# Altium schematic sheet current_sens.SchDoc("Current sens 5V")
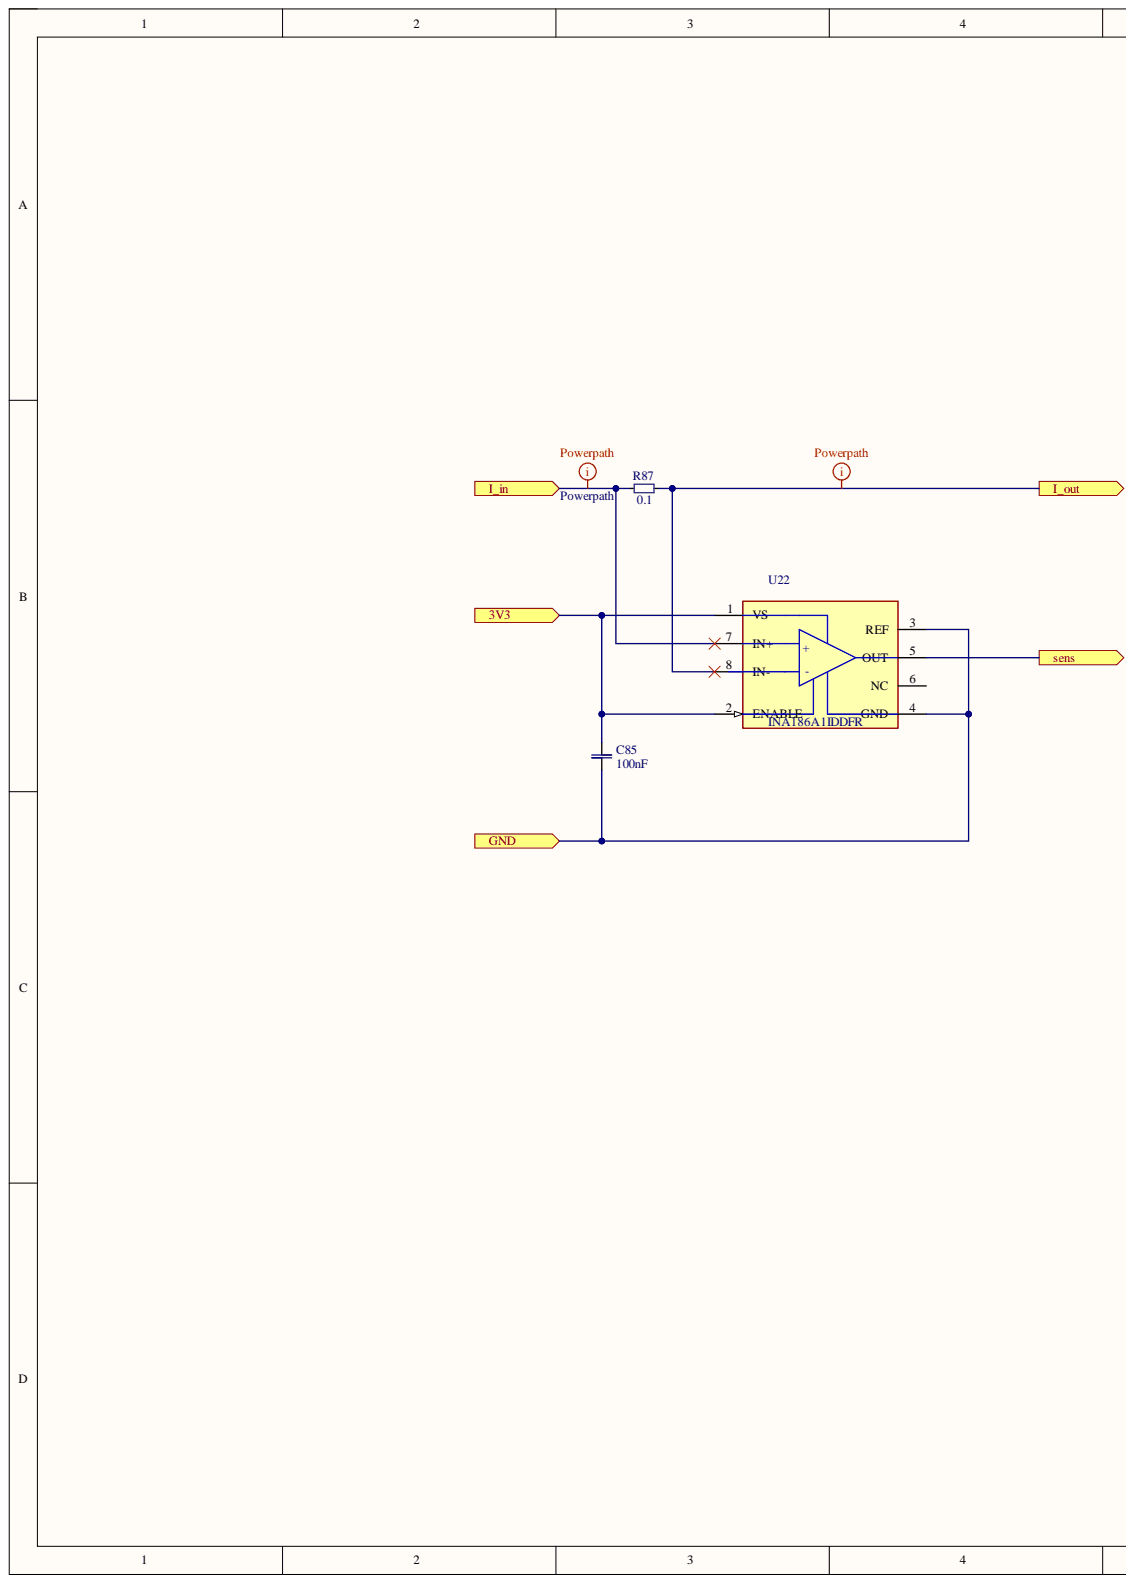
[diagram p0: current_sens.SchDoc, left]
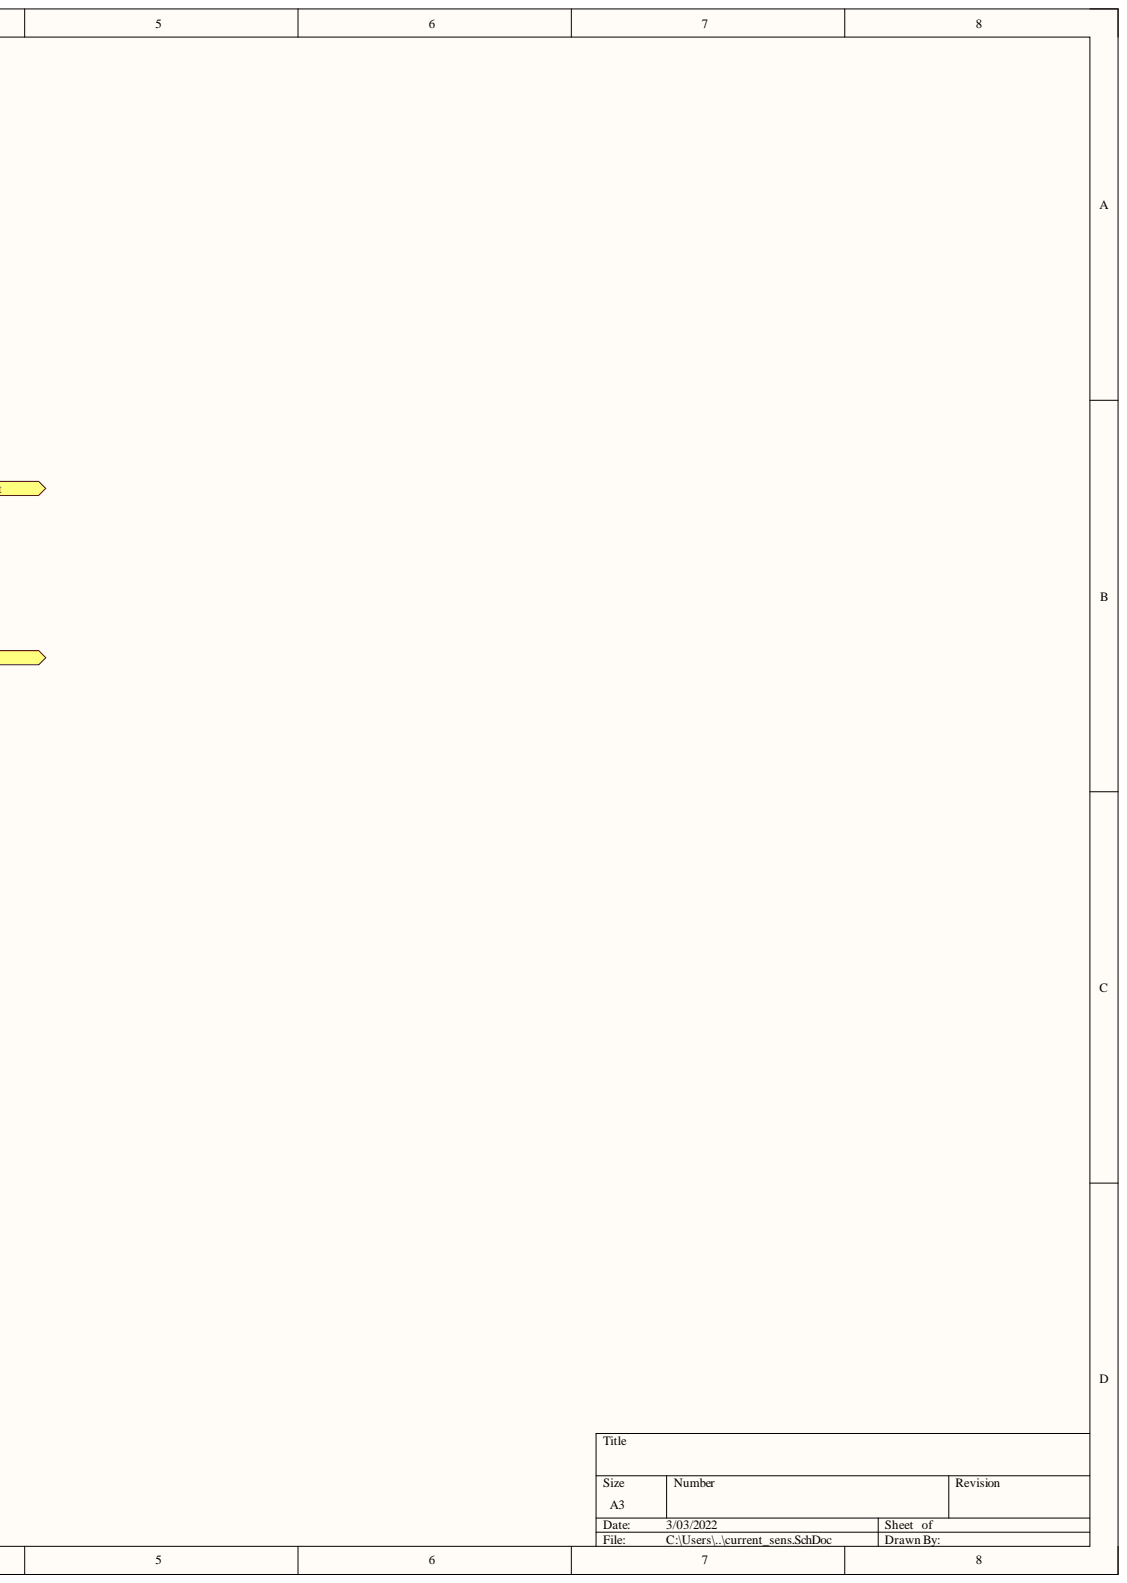
[diagram p1: current_sens.SchDoc, right]

## Parts
C85  value 100nF  Capacitance: 100nF  [cap0402]  (hidden comment: GRM155R71H104ME14D)
R87  value 0.1  Resistance: 0.1  [Chip2PinSM127P600-8N]  (hidden comment: ERJ-8BSFR10V)
U22  INA186A1IDDFR  [FP-DDF0008A-IPC_C]

## Ports
Current sens 5V_3V3, Current sens 5V_GND, Current sens 5V_I_in, Current sens 5V_I_out, Current sens 5V_sens

## Nets
NetC85_1: C85.1 U22.3(REF) U22.4(GND)
NetC85_2: C85.2 U22.1(VS) U22.2(ENABLE)
NetR87_1: R87.1 U22.7(IN+)
NetR87_2: R87.2 U22.8(IN-)
NetU22_5: U22.5(OUT)

Not connected: U22 6(NC)

## Library data of the parts
C85 = CMP-0001-00001-9: 0402 0.1 uF 50 V ±20% Tolerance X7R SMT Monolithic Ceramic Chip Capacitor; Voltage Rating: 50V; Capacitor Type: Ceramic; Case/Package: 0402; Component Kind: Standard; Length: 0.039inch; Library Name: concord server; Max Operating Temperature: 125°C; Min Operating Temperature: -55°C; Mount: Surface Mount; Packaging: Cut Tape; Pins: 2; RoHS: Yes; Thickness: 0.022inch; Tolerance: 20%; Width: 0.02inch
R87 = CMP-0001-00003-3: Precision resistor, SMD 0.1 Ohm 0.33 W  ±  1 % 1206, ERJ8BSFR10V, Panasonic Automotive & Industrial Systems; Max Power Dissipation: 500mW; Power Rating: 330mW; Temperature Coefficient: 250ppm/°C; Tolerance: 1%; Case Code (Imperial): 1206; Case Code (Metric): 3216; Case/Package: 1206; Component Kind: Standard; Composition: Thick Film,Low-Ohm-Resistor; Contact Plating: Tin; Depth: 1.6mm; Features: Automotive AEC-Q200; Height: 0.6mm; Length: 3.2mm; Library Name: concord server; Max Operating Temperature: 125°C; Military Standard: Not; Min Operating Temperature: -55°C; Mount: Surface Mount; Number of Pins: 2; Number of Terminations: 2; Package Quantity: 5000; Packaging: Tape and Reel; Radiation Hardening: No; REACH SVHC: No SVHC; RoHS: Yes; Termination: SMD/SMT; Width: 0.063inch
U22 = CMP-ROOT-00032-5: 40V, bi-directional, high-precision current sense amplifier w/ picoamp IB & ENABLE 8-SOT-23-THIN -40 to 125; Case/Package: SOT-23-8; Component Kind: Standard; Library Name: concord server; Mount: SMD; RoHS: Yes
